# Altium schematic sheet [15] Sensors.SchDoc(BLK4)
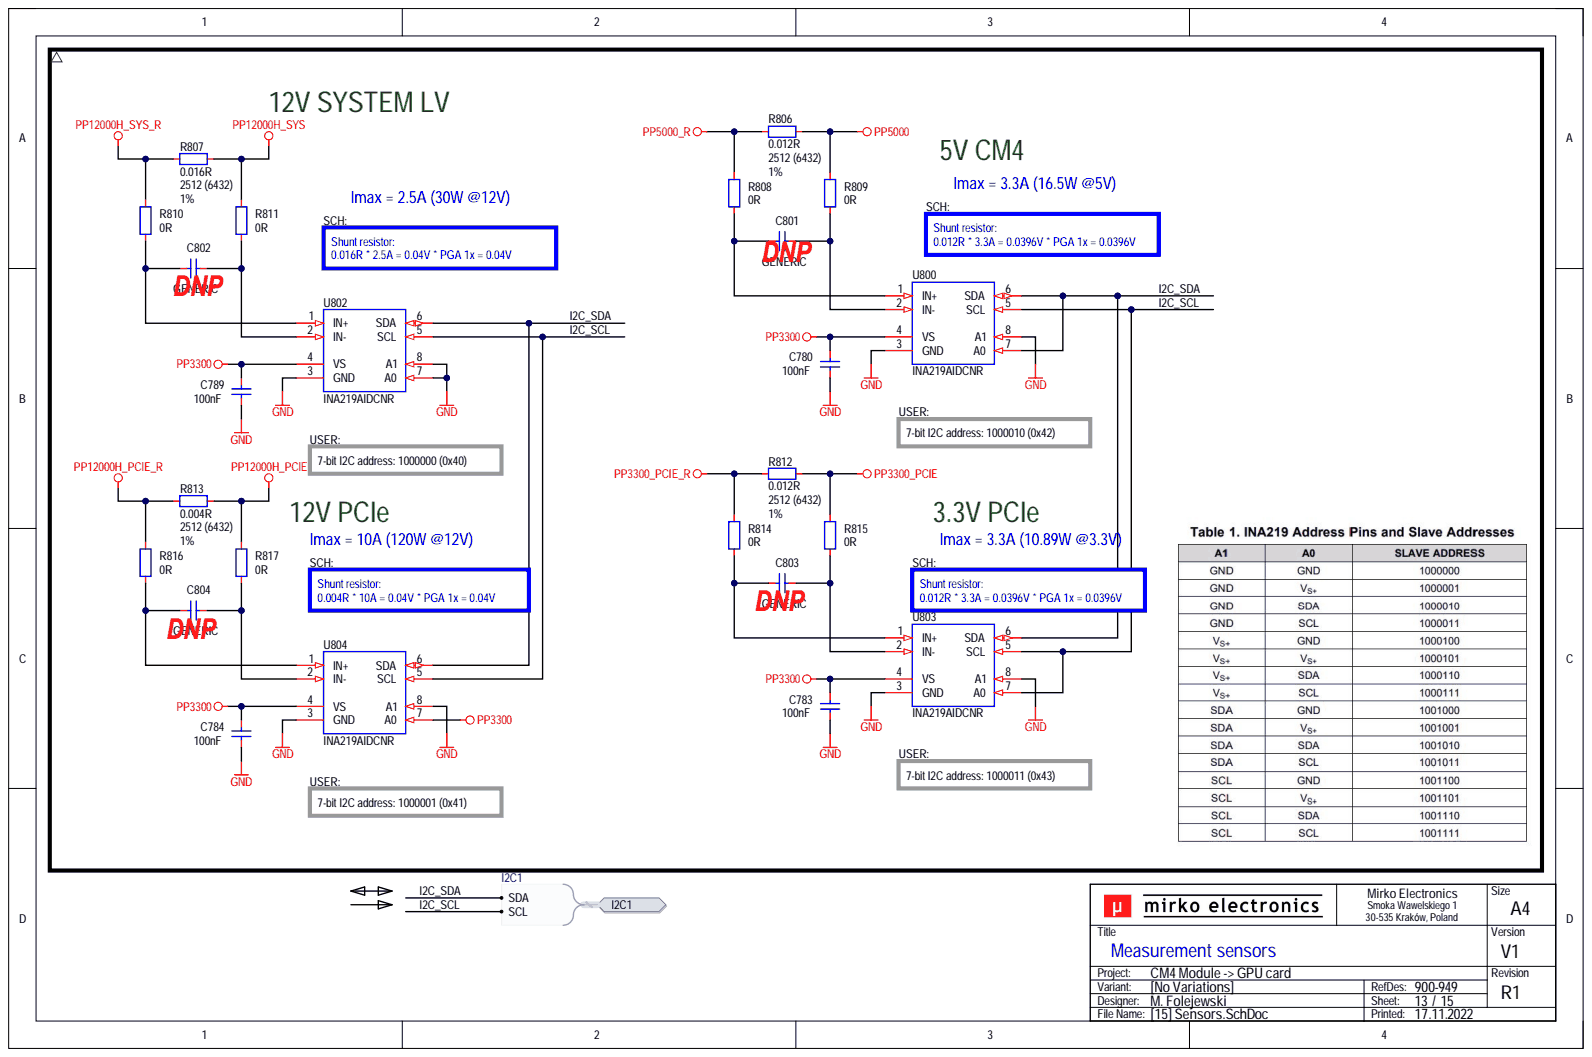
[diagram p0: [15] Sensors.SchDoc]

## Parts
C780  100nF  [CAP_0603_R]
C783  100nF  [CAP_0603_R]
C784  100nF  [CAP_0603_R]
C789  100nF  [CAP_0603_R]
C801  GENERIC  [CAP_0603_R]
C802  GENERIC  [CAP_0603_R]
C803  GENERIC  [CAP_0603_R]
C804  GENERIC  [CAP_0603_R]
R806  0.012R  [RES_1206_R]
R807  0.016R  [RES_1206_R]
R808  0R  [RES_0603_R]
R809  0R  [RES_0603_R]
R810  0R  [RES_0603_R]
R811  0R  [RES_0603_R]
R812  0.012R  [RES_1206_R]
R813  0.004R  [RES_1206_R]
R814  0R  [RES_0603_R]
R815  0R  [RES_0603_R]
R816  0R  [RES_0603_R]
R817  0R  [RES_0603_R]
U800  INA219AIDCNR  [ICC_SOT23-8_290X160X100P65]
U802  INA219AIDCNR  [ICC_SOT23-8_290X160X100P65]
U803  INA219AIDCNR  [ICC_SOT23-8_290X160X100P65]
U804  INA219AIDCNR  [ICC_SOT23-8_290X160X100P65]

## Ports
I2C1, I2C1.SCL, I2C1.SDA

## Nets
GND: C780.1 C783.1 C784.1 C789.1 U800.3(GND) U800.8(A1) U802.3(GND) U802.7(A0) U802.8(A1) U803.3(GND) U803.8(A1) U804.3(GND) U804.8(A1)
PP3300: C780.2 C783.2 C784.2 C789.2 U800.4(VS) U802.4(VS) U803.4(VS) U804.4(VS) U804.7(A0)
PP3300_PCIE: R812.2 R815.2
PP3300_PCIE_R: R812.1 R814.2
PP5000: R806.2 R809.2
PP5000_R: R806.1 R808.2
PP12000H_PCIE: R813.2 R817.2
PP12000H_PCIE_R: R813.1 R816.2
PP12000H_SYS: R807.2 R811.2
PP12000H_SYS_R: R807.1 R810.2
I2C_SCL: U800.5(SCL) U802.5(SCL) U803.5(SCL) U803.7(A0) U804.5(SCL) ; port I2C1
I2C_SDA: U800.6(SDA) U800.7(A0) U802.6(SDA) U803.6(SDA) U804.6(SDA) ; port I2C1
NetC801_1: C801.1 R808.1 U800.1(IN+)
NetC801_2: C801.2 R809.1 U800.2(IN-)
NetC802_1: C802.1 R810.1 U802.1(IN+)
NetC802_2: C802.2 R811.1 U802.2(IN-)
NetC803_1: C803.1 R814.1 U803.1(IN+)
NetC803_2: C803.2 R815.1 U803.2(IN-)
NetC804_1: C804.1 R816.1 U804.1(IN+)
NetC804_2: C804.2 R817.1 U804.2(IN-)

## Library data of the parts
C780 C783 C784 C789 = CAP-0008: Capacitor; MLCC; 100nF; TBD; TBD; TBD; TBD; SMD; 0603 (1608);; Database Table Name: CAPACITOR$; Component Kind: Standard; Component Subtype: MLCC; Component Type: Capacitor; Manufacturer: TBD; Mounting Type: SMD; MPN: TBD; Package (Case): 0603 (1608); Supplier: ?; Value: 100nF; Library Name: MirFol_db_local.DbLib
C801-C804 = CAP-0023: Capacitor; MLCC; GENERIC; TBD; TBD; TBD; TBD; SMD; 0603 (1608);; Database Table Name: CAPACITOR$; Capacitance: GENERIC; Component Body: TBD; Component Height: TBD; Component Kind: Standard; Component Subtype: MLCC; Component Type: Capacitor; Dielectric: TBD; Features: TBD; ID: CAP-0023; Manufacturer: GENERIC; Mounting Type: SMD; MPN: GENERIC; Operating Temperature: TBD; Package (Case): 0603 (1608); Packaging Type: TBD; ROHS Status: TBD; Tolerance: TBD; Value: GENERIC; Voltage Rating: TBD; Library Name: MirFol_db_local.DbLib
R806 R807 R812 R813 = RES-0060: Resistor; Thick Film; GENERIC; TBD; TBD; TBD; TBD; TBD; SMD; 2512 (6432);; Database Table Name: RESISTOR$; Tolerance: 1%; Component Body: TBD; Component Height: TBD; Component Kind: Standard; Component Subtype: Thick Film; Component Type: Resistor; Features: TBD; ID: RES-0060; Manufacturer: GENERIC; Mounting Type: SMD; MPN: GENERIC; Operating Temperature: TBD; Package (Case): 2512 (6432); Packaging Type: TBD; Power Rating: TBD; ROHS Status: TBD; TCR: TBD; Voltage Rating: TBD; Library Name: MirFol_db_local.DbLib
  R806 R812: Resistance: 0.012R; Value: 0.012R
  R807: Resistance: 0.016R; Value: 0.016R
  R813: Resistance: 0.004R; Value: 0.004R
R808-R811 R814-R817 = RES-0052: Resistor; Thick Film; 0R; 1%; TBD; TBD; TBD; Standard; SMD; 0603 (1608);; Component Body: 1.60 x 0.80mm; Database Table Name: RESISTOR$; Component Height: 0.45mm; Component Kind: Standard; Component Subtype: Thick Film; Component Type: Resistor; Features: Standard; ID: RES-0052; Manufacturer: TBD; Mounting Type: SMD; MPN: TBD; Operating Temperature: TBD; Package (Case): 0603 (1608); Packaging Type: TBD; Power Rating: TBD; Resistance: 0R; ROHS Status: TBD; TCR: TBD; Tolerance: 1%; Value: 0R; Voltage Rating: TBD; Library Name: MirFol_db_local.DbLib
U800 U802-U804 = ICC_TXI_INA219_SOT23-8: IC; Current Monitor;; Manufacturer: Texas Instruments; Specification: Bidirectional Current/Power Monitor, I2C, SOT-23-8 package; Component Kind: Standard; Component Subtype: Current monitor; Component Type: IC; Mounting Type: SMD; MPN: INA219AIDCNR; Operating Temperature: -40°C to +85°C; Package: SOT-23-8; Value: INA219AIDCNR; Library Name: Pineberry_Lib.SchLib
